# Altium schematic sheet [4]gpio.SchDoc([4]gpio)
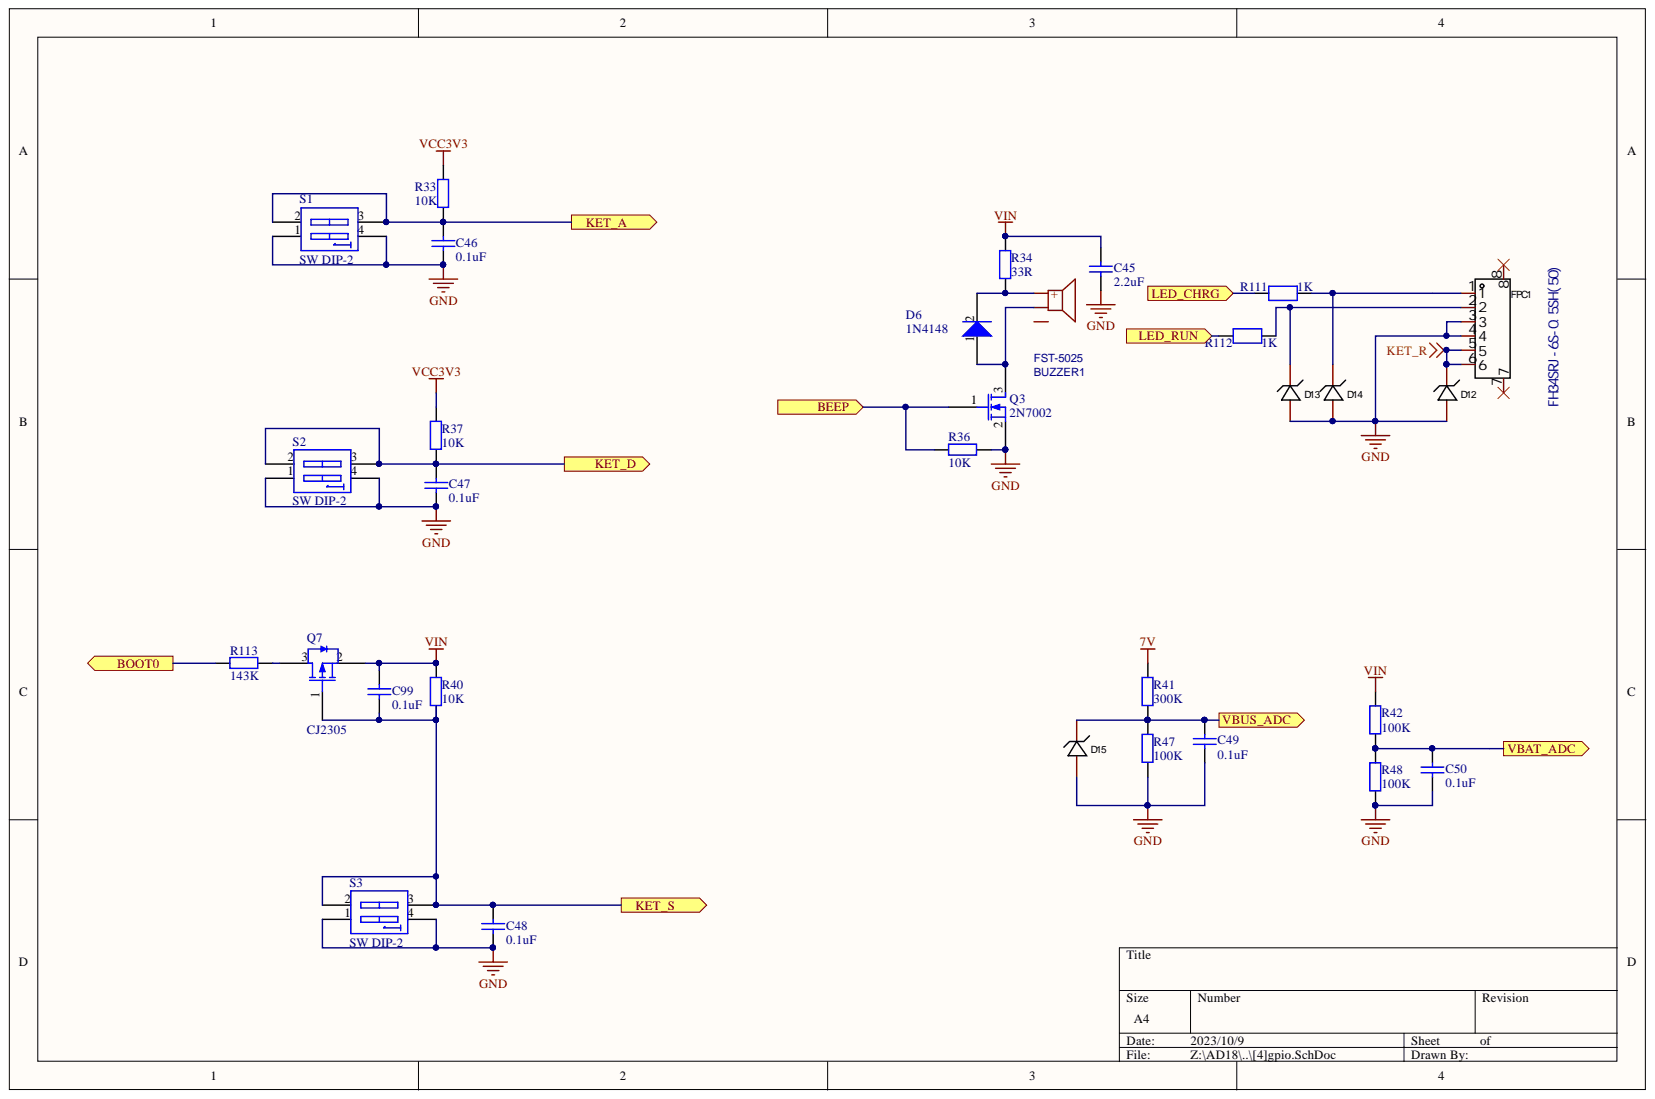
[diagram p0: [4]gpio.SchDoc]

## Parts
BUZZER1  FST-5025  [buzz]
C45  2.2uF  [C0603]
C46  0.1uF  [C0402]
C47  0.1uF  [C0402]
C48  0.1uF  [C0402]
C49  0.1uF  [C0402]
C50  0.1uF  [C0402]
C99  0.1uF  [0402]
D6  1N4148  [SOD523]
D12  TPD1E05U06DPYR  [X2SON-2_L1.0-W0.6-P0.70-RD]  (value not drawn)
D13  TPD1E05U06DPYR  [X2SON-2_L1.0-W0.6-P0.70-RD]  (value not drawn)
D14  TPD1E05U06DPYR  [X2SON-2_L1.0-W0.6-P0.70-RD]  (value not drawn)
D15  TPD1E05U06DPYR  [X2SON-2_L1.0-W0.6-P0.70-RD]  (value not drawn)
FPC1  FH34SRJ-6S-0.5SH(50)  [FFC-SMD_6P-P0.50_FH34SRJ-6S-0.5SH]
Q3  2N7002  [SOT-23]
Q7  CJ2305  [SOT-23(SOT-23-3)]
R33  10K  [R0402]
R34  33R  [R0402]
R36  10K  [R0402]
R37  10K  [R0402]
R40  10K  [0402]
R41  300K  [R0402]
R42  100K  [R0402]
R47  100K  [R0402]
R48  100K  [R0402]
R111  1K  [0402_RES]
R112  1K  [0402_RES]
R113  143K  [0402]
S1  SW DIP-2  [SW3X3A]
S2  SW DIP-2  [SW3X3A]
S3  SW DIP-2  [SW3X3A]

## Ports
BEEP, BOOT0, KET_A, KET_D, KET_S, LED_CHRG, LED_RUN, VBAT_ADC, VBUS_ADC

## Nets
7V: R41.1
GND: C45.2 C46.1 C47.1 C48.1 C49.1 C50.1 D12.2 D13.2 D14.2 D15.2 FPC1.3 FPC1.4 Q3.2 R36.2 R47.2 R48.2 S1.1 S1.4 S2.1 S2.4 S3.1 S3.4
KET_R: D12.1 FPC1.5 FPC1.6
VCC3V3: R33.1 R37.1
VIN: C45.1 C99.1 Q7.2 R34.1 R40.2 R42.1
BEEP: Q3.1 R36.1 ; port BEEP
BOOT0: R113.1 ; port BOOT0
KET_A: C46.2 R33.2 S1.2 S1.3 ; port KET_A
KET_D: C47.2 R37.2 S2.2 S2.3 ; port KET_D
KET_S: C48.2 C99.2 Q7.1 R40.1 S3.2 S3.3 ; port KET_S
LED_CHRG: R111.2 ; port LED_CHRG
LED_RUN: R112.2 ; port LED_RUN
VBAT_ADC: C50.2 R42.2 R48.1 ; port VBAT_ADC
VBUS_ADC: C49.2 D15.1 R41.2 R47.1 ; port VBUS_ADC
NetBUZZER1_1: BUZZER1.1 D6.2 R34.2
NetBUZZER1_2: BUZZER1.2 D6.1 Q3.3
NetD13_1: D13.1 FPC1.2 R112.1
NetD14_1: D14.1 FPC1.1 R111.1
NetQ7_3: Q7.3 R113.2

Not connected: BUZZER1 3; FPC1 7 8

## Library data of the parts
BUZZER1 = FST-5020: BOM_Manufacturer: FUET; Frequency: 4000Hz; Contributor: LCSC; spiceSymbolName: FST-5020; spicePre: B; Component Kind: Standard; BOM_Supplier Part: C391029; BOM_Supplier: LCSC; BOM_Manufacturer Part: FST-5020; BOM_JLCPCB Part Class: Extended Part
C45-C50 = 0603 10uF (106) 10% 10V: 贴片电容 10% X7R 16V; ManufacturerNumber: CL05B104KO5NNND; Manufacturer: SAMSUNG; SuppliersPartNumber: C134153; Library Name: Cap.SchLib; Component Kind: Standard
C99 = 0603 10uF (106): 贴片电容 10% X7R 16V; ManufacturerNumber: CL05B104KO5NNND; Manufacturer: SAMSUNG; SuppliersPartNumber: C134153; Library Name: Cap.SchLib; Component Kind: Standard
D6 = SO: 开关二极管 75V 150mA trr=4ns VF=1.25V@150mA PD=400mW; ManufacturerNumber: 1N4148WT; Manufactu: CJ江苏长电; SuppliersPartNumber: C68948; Library Name: Diode.SchLib; Component Kind: Standard
D12-D15 = TPD1E05U06DPYR: TVS 单向   反向截止电压(Vrwm)5.5V@Max  击穿电压6V  峰值脉冲电流(Ipp)@10/1000μs  2.5A@8/20us   最大钳位电压14V; Manufacturer: TI(德州仪器); Maximum Clamping Voltage: 14V; Convert to PCB: yes; Breakdown Voltage: 6V; Symbol: TPD1E05U06DPYR; Supplier Part: C436349; Supplier Footprint: X2-SON-2(0.6x1); Supplier: LCSC; Reverse Stand-Off Voltage (Vrwm): 5.5V@Max; Polarity: 单向; Peak Pulse Current (Ipp)@10/1000μs: 2.5A@8/20us; PCB3D: X2SON-2_L1.0-W0.6-H0.4-P0.70-RD; Name: TPD1E05U06DPYR; Manufacturer Part: TPD1E05U06DPYR; Library Name: HiPin_Library_AD.IntLib; LCSC Part Name: 单向 Vrwm:5.5V@Max; JLCPCB Part Class: Extended Part; Device: TPD1E05U06DPYR; Datasheet: https://atta.szlcsc.com/upload/public/pdf/source/20191016/C436349_486749D4EDA8974C8ED360A45B982565.pdf; Component Kind: Standard; Add into BOM: yes
FPC1 = FH34SRJ-6S-0.5SH(50): Manufacturer: HRS(广濑); Pitch: 0.5mm; Number of Contacts: 6; FFC, FCB Thickness: 0.3mm~0.3mm; Convert to PCB: yes; Symbol: FH34SRJ-6S-0.5SH(50); Supplier Part: C224194; Supplier Footprint: SMD; Supplier: LCSC; PCB3D: FFC-SMD_P0.50-WS-6P; Name: FH34SRJ-6S-0.5SH(50); Mounting Type: 卧贴; Manufacturer Part: FH34SRJ-6S-0.5SH(50); Locking Feature: -; Library Name: HiPin_Library_AD.IntLib; LCSC Part Name: FH34SRJ-6S-0.5SH(50); JLCPCB Part Class: Extended Part; Height Above Board: 1mm; Device: FH34SRJ-6S-0.5SH(50); Datasheet: https://atta.szlcsc.com/upload/public/pdf/source/20180712/C224194_08937A353F43080B85824A8384727686.pdf; Contact Type: 双侧触点/上下接; Component Kind: Standard; Add into BOM: yes
Q3 = MOSFET-N: NMOS 60V 0.115A 7Ω@10V; Manufacturer: CJ江苏长电; SuppliersPartNumber: C8545; Package: SOT-23; ManufacturerNumber: 2N7002; Library Name: Miscellaneous Devices.IntLib; Component Kind: Standard
Q7 = AO3401A P道沟: P沟道,-12V,-4.1A,45mΩ@-4.5V; Manufacturer: CJ江苏长电; SuppliersPartNumber: C8549; ManufacturerNumber: CJ2305; Library Name: Miscellaneous Devices LC.IntLib; Component Kind: Standard
R33 R37 = 0603 49.9KΩ (4992) 1%: 贴片电阻 1% 1/16W 100ppm; ManufacturerNumber: RC0402FR-0710KL; Manufacturer: YAGEO; SuppliersPartNumber: C60490; Library Name: RES.SchLib; Component Kind: Standard
R34 R36 R41 R42 R47 R48 = 0603 49.9KΩ (4992) 1%: 贴片电阻 1% 1/16W 200ppm; ManufacturerNumber: RC0402FR-0710KL; Manufacturer: YAGEO; SuppliersPartNumber: C60490; Library Name: RES.SchLib; Component Kind: Standard
R40 R113 = 0603 49.9KΩ: 贴片电阻 1% 1/16W 200ppm; ManufacturerNumber: RC0402FR-07100KL; Manufacturer: YAGEO; SuppliersPartNumber: C60491; Library Name: RES.SchLib; Component Kind: Standard
R111 R112 = RES: Resistor; Library Name: HiPin_Library_AD.IntLib; Component Kind: Standard
S1-S3 = SW DIP-2: DIP Switch; ManufacturerNumber: TS-A007(3*3*2.0H-J); Component Kind: Standard
